# Altium schematic sheet TOP.SchDoc(TOP)
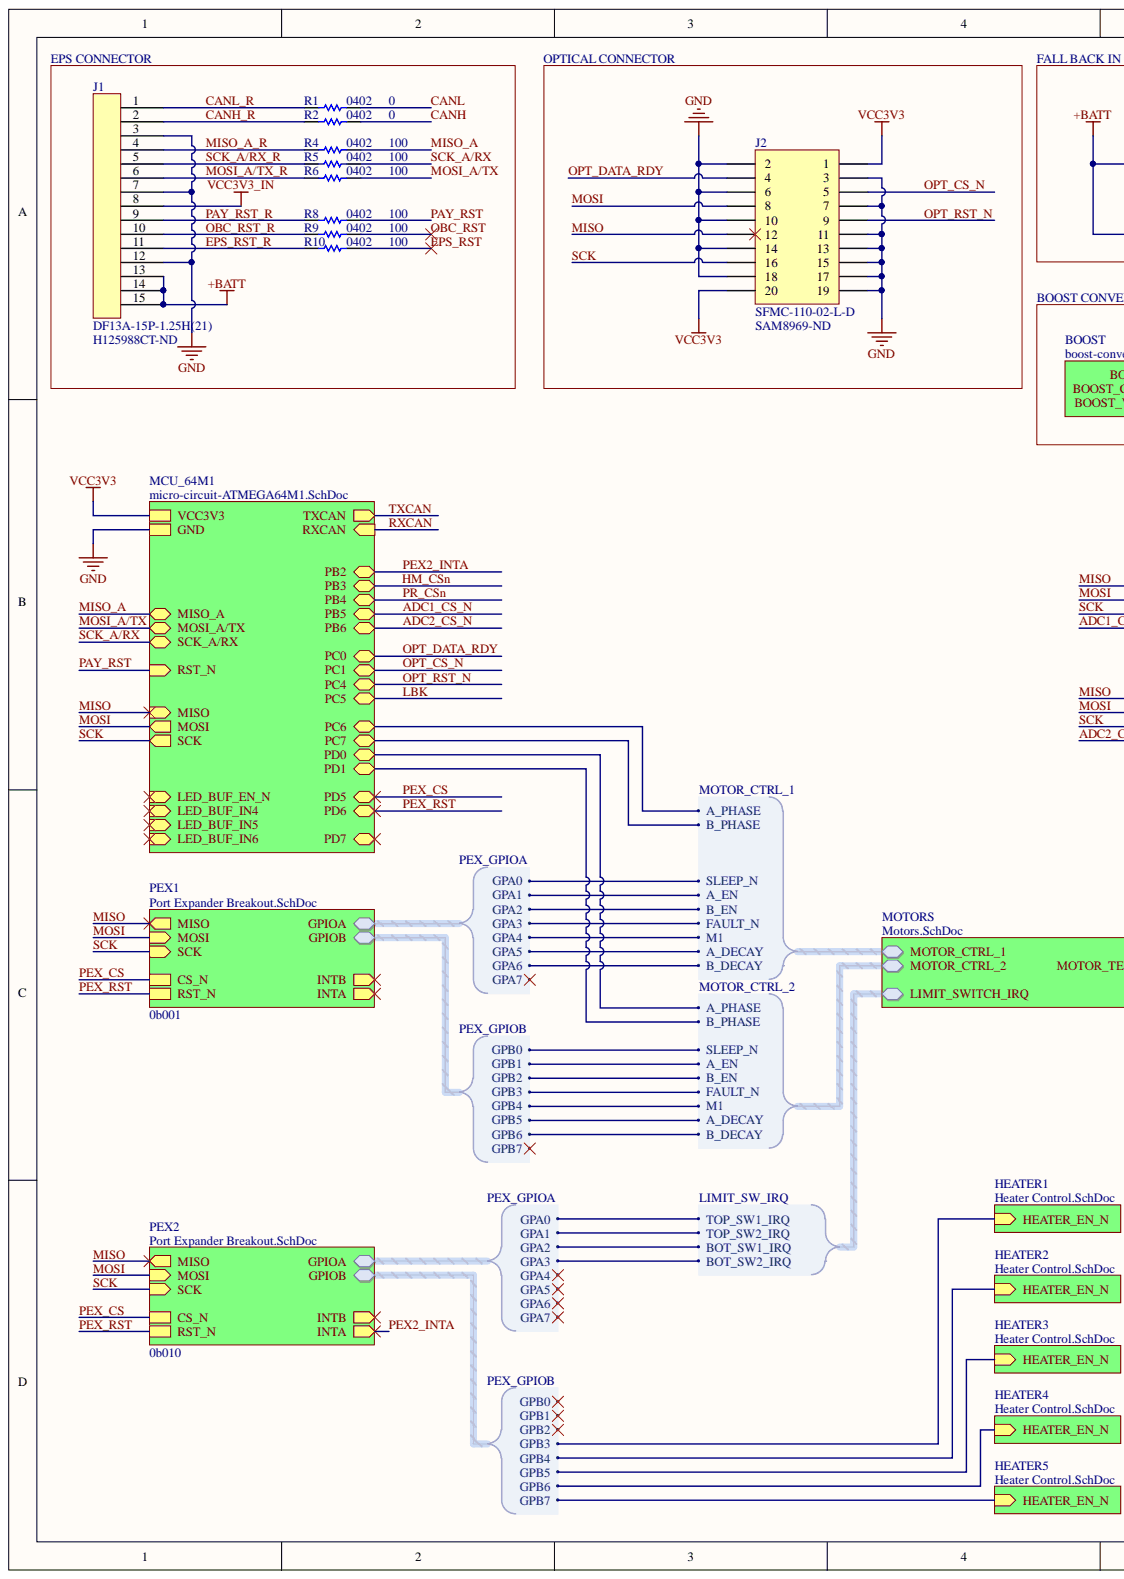
[diagram p0: TOP.SchDoc, left]
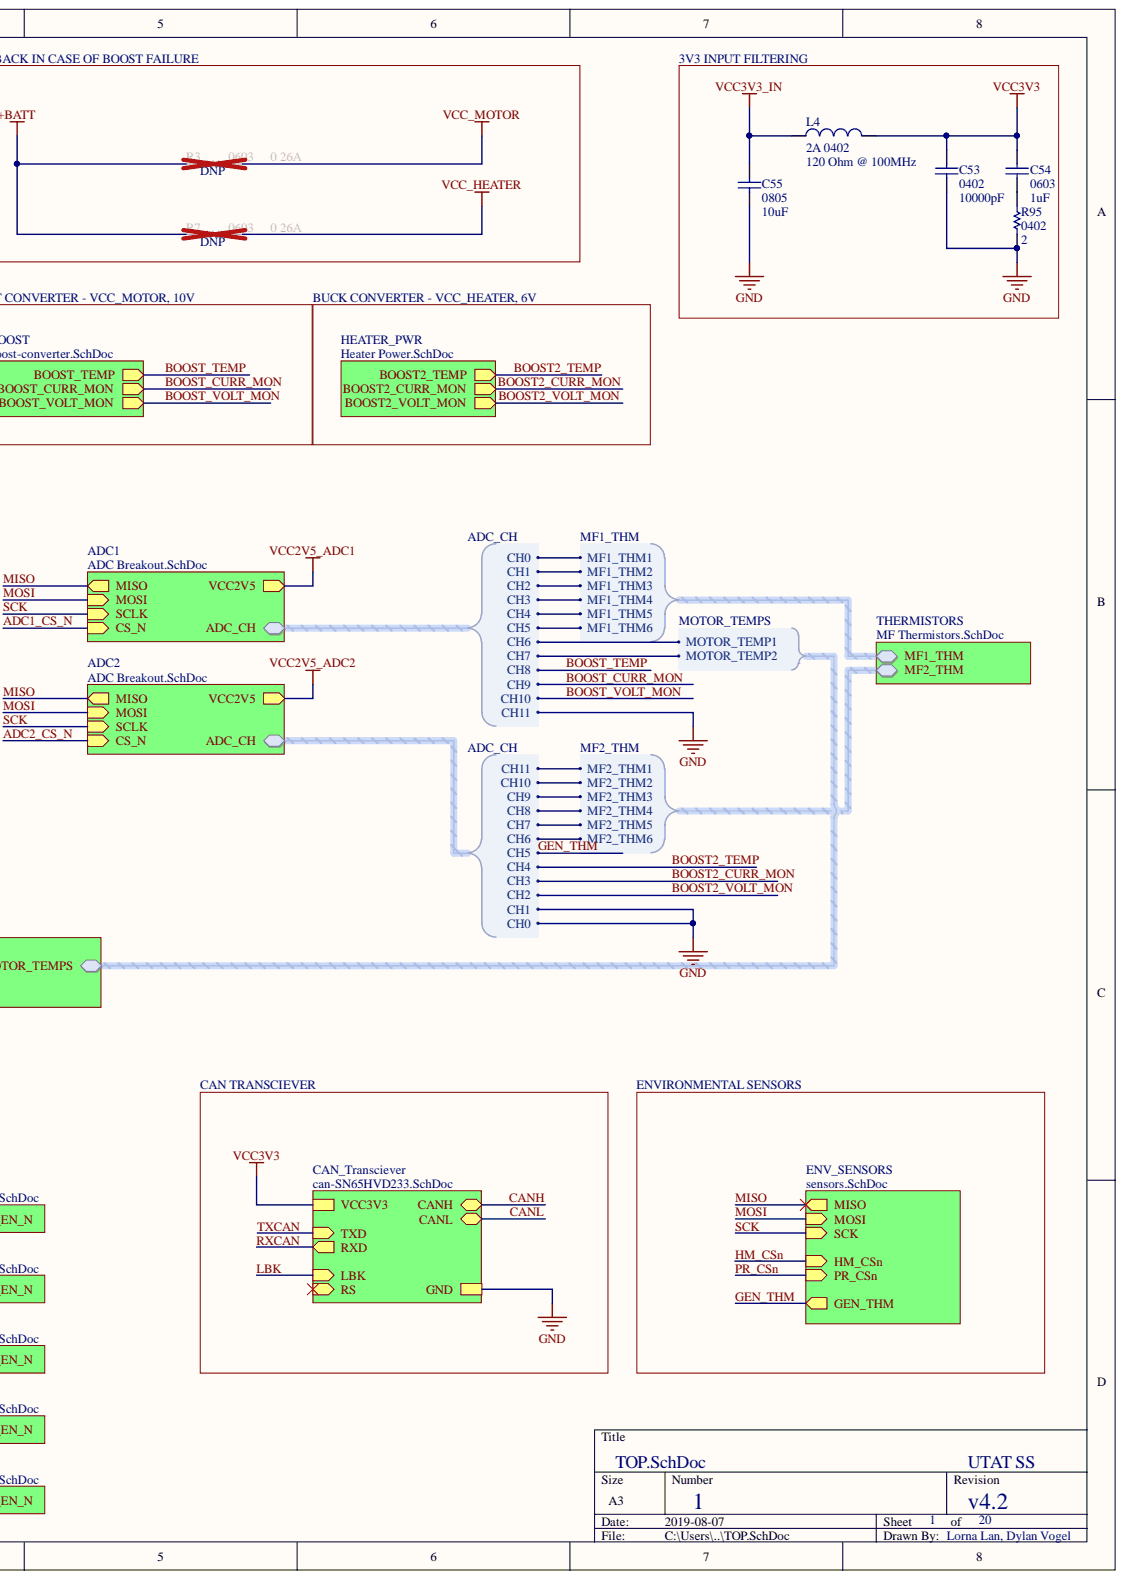
[diagram p1: TOP.SchDoc, right]

## Parts
C53  0402  value 10000pF  [CAP0402R]
C54  0603  value 1uF  [CAP0603R]
C55  0805  value 10uF  [CAP0805R]
J1  DF13A-15P-1.25H(21)  Supplier Part Number 1: H125988CT-ND  [DF13A-15P-1.25H(21)]
J2  SFMC-110-02-L-D  Supplier Part Number 1: SAM8969-ND  [SFMC-110-02-L-D]
L4  2A 0402  value 120 Ohm @ 100MHz  Max Current Rating: 2A  Code_JEITA: 0402  [RES0402R]
R1  0402  value 0  [RES0402R]
R2  0402  value 0  [RES0402R]
R3  0603  value 0 26A  [RES0603R]
R4  0402  value 100  [RES0402R]
R5  0402  value 100  [RES0402R]
R6  0402  value 100  [RES0402R]
R7  0603  value 0 26A  [RES0603R]
R8  0402  value 100  [RES0402R]
R9  0402  value 100  [RES0402R]
R10  0402  value 100  [RES0402R]
R95  0402  value 2  [RES0402R]

## Nets
+BATT: J1.13 J1.14 J1.15 R3.1 R7.1
GND: C53.1 C55.1 J1.3 J1.7 J1.12 J2.2 J2.3 J2.6 J2.7 J2.10 J2.11 J2.13 J2.14 J2.15 J2.17 J2.18 J2.19 R95.1
VCC3V3: C53.2 C54.2 J2.1 J2.20 L4.2
VCC3V3_IN: C55.2 J1.8 L4.1
VCC_HEATER: R7.2
VCC_MOTOR: R3.2
CANH: R2.2
CANH_R: J1.2 R2.1
CANL: R1.2
CANL_R: J1.1 R1.1
EPS_RST: R10.2
EPS_RST_R: J1.11 R10.1
MISO: J2.12
MISO_A: R4.2
MISO_A_R: J1.4 R4.1
MOSI: J2.8
MOSI_A/TX: R6.2
MOSI_A/TX_R: J1.6 R6.1
OBC_RST: R9.2
OBC_RST_R: J1.10 R9.1
OPT_CS_N: J2.5
OPT_DATA_RDY: J2.4
OPT_RST_N: J2.9
PAY_RST: R8.2
PAY_RST_R: J1.9 R8.1
SCK: J2.16
SCK_A/RX: R5.2
SCK_A/RX_R: J1.5 R5.1
NetC54_1: C54.1 R95.2
ADC1_CS_N:
ADC2_CS_N:
BOOST2_CURR_MON:
BOOST2_TEMP:
BOOST2_VOLT_MON:
BOOST_CURR_MON:
BOOST_TEMP:
BOOST_VOLT_MON:
GEN_THM:
HM_CSn:
LBK:
N00692:
N00693:
N00694:
N00695:
N00696:
N00697:
N00698:
N00699:
N00700:
N00701:
N00702:
N00703:
N00704:
N00705:
N00706:
N00707:
N00708:
N00709:
N00710:
N00711:
N00712:
N00713:
N00714:
N00715:
N00716:
N00717:
N00718:
N00719:
N00720:
N00721:
N00722:
N00723:
N00724:
N00725:
N00726:
N00727:
N00728:
N00729:
N00730:
N00731:
N00732:
N00733:
N00734:
N00735:
N00736:
N00737:
N00738:
N00739:
N00740:
N00741:
N00742:
N00743:
N00744:
N00745:
N00746:
N00747:
N00748:
N00749:
N00750:
PEX2_INTA:
PEX_CS:
PEX_RST:
PR_CSn:
RXCAN:
TXCAN:
VCC2V5_ADC1:
VCC2V5_ADC2:

## Library data of the parts
C53-C55 = Cap: Capacitor; Simulation: CAP; Signal Integrity: Cap; Published: 8-Jun-2000; PackageReference: RAD-0.3; PackageDescription: Radial Cap, Thru-Hole; 2 Leads; 0.3 in Pin Spacing; Library Name: Miscellaneous Devices.IntLib; LatestRevisionNote: Re-released for DXP Platform.; LatestRevisionDate: 17-Jul-2002; Component Kind: Standard; Publisher: Altium Limited
J1 = DF13A-15P-1.25H(21): 15 pos DF13 right angle surface mount connector; Supplier 1: Digi-Key; Component Kind: Standard; Library Name: heron-general.IntLib
L4 = Inductor: Manufacturer Part Number: BLM15PX121SZ1D; Manufacturer: Murata; Number of Lines: 1; DC Resistance (DCR): 55mR; Code_IEC: 1005; Supplier Part Number 1: 490-16505-1-ND; Supplier 1: Digi-Key; Stock 1: 18936; Simulation: INDUCTOR; Signal Integrity: Inductor; Ratings: AEC-Q200; Published: 8-Jun-2000; Pricing 1: 1=0.15, 10=0.125, 25=0.0972, 50=0.0804, 100=0.0693, 250=0.05544, 500=0.0499, 1000=0.04158, 5000=0.03881 (USD); Packaging: Cut Tape; PackageReference: 0402-A; PackageDescription: Chip Inductor; Mount: Surface Mount; Min Operating Temperature: -55°C; Max Operating Temperature: 125°C; Library Name: Miscellaneous Devices.IntLib; LatestRevisionNote: IPC-7351 Footprint Added.; LatestRevisionDate: 29-May-2009; Description: Inductor; Component Kind: Standard; Case Code (Metric): 1005; Case Code (Imperial): 0402; Case/Package: 0402; Publisher: Altium Limited
R1 R2 R4-R6 R8-R10 = RES0402: 0402 resistor; Simulation: RESISTOR; Signal Integrity: Res; Component Kind: Standard; Library Name: heron-general.IntLib
R3 R7 = RES0603: 0603 resistor; Supplier Part Number 1: 2019-TLRZ1JTTDCT-ND; Supplier 1: Digi-Key; Simulation: RESISTOR; Signal Integrity: Res; Component Kind: Standard; Library Name: heron-general.IntLib
R95 = Res1: Resistor; Simulation: RESISTOR; Signal Integrity: Res; Published: 8-Jun-2000; PackageReference: AXIAL-0.3; PackageDescription: Axial Device, Thru-Hole; 2 Leads; 0.3 in Pin Spacing; Library Name: Miscellaneous Devices.IntLib; LatestRevisionNote: Re-released for DXP Platform.; LatestRevisionDate: 17-Jul-2002; Component Kind: Standard; Publisher: Altium Limited
J2 = SFMC-110-02-L-D: Supplier 1: Digi-Key; Component Kind: Standard; Library Name: heron-general.IntLib
